# Altium schematic sheet Sheet.SchDoc
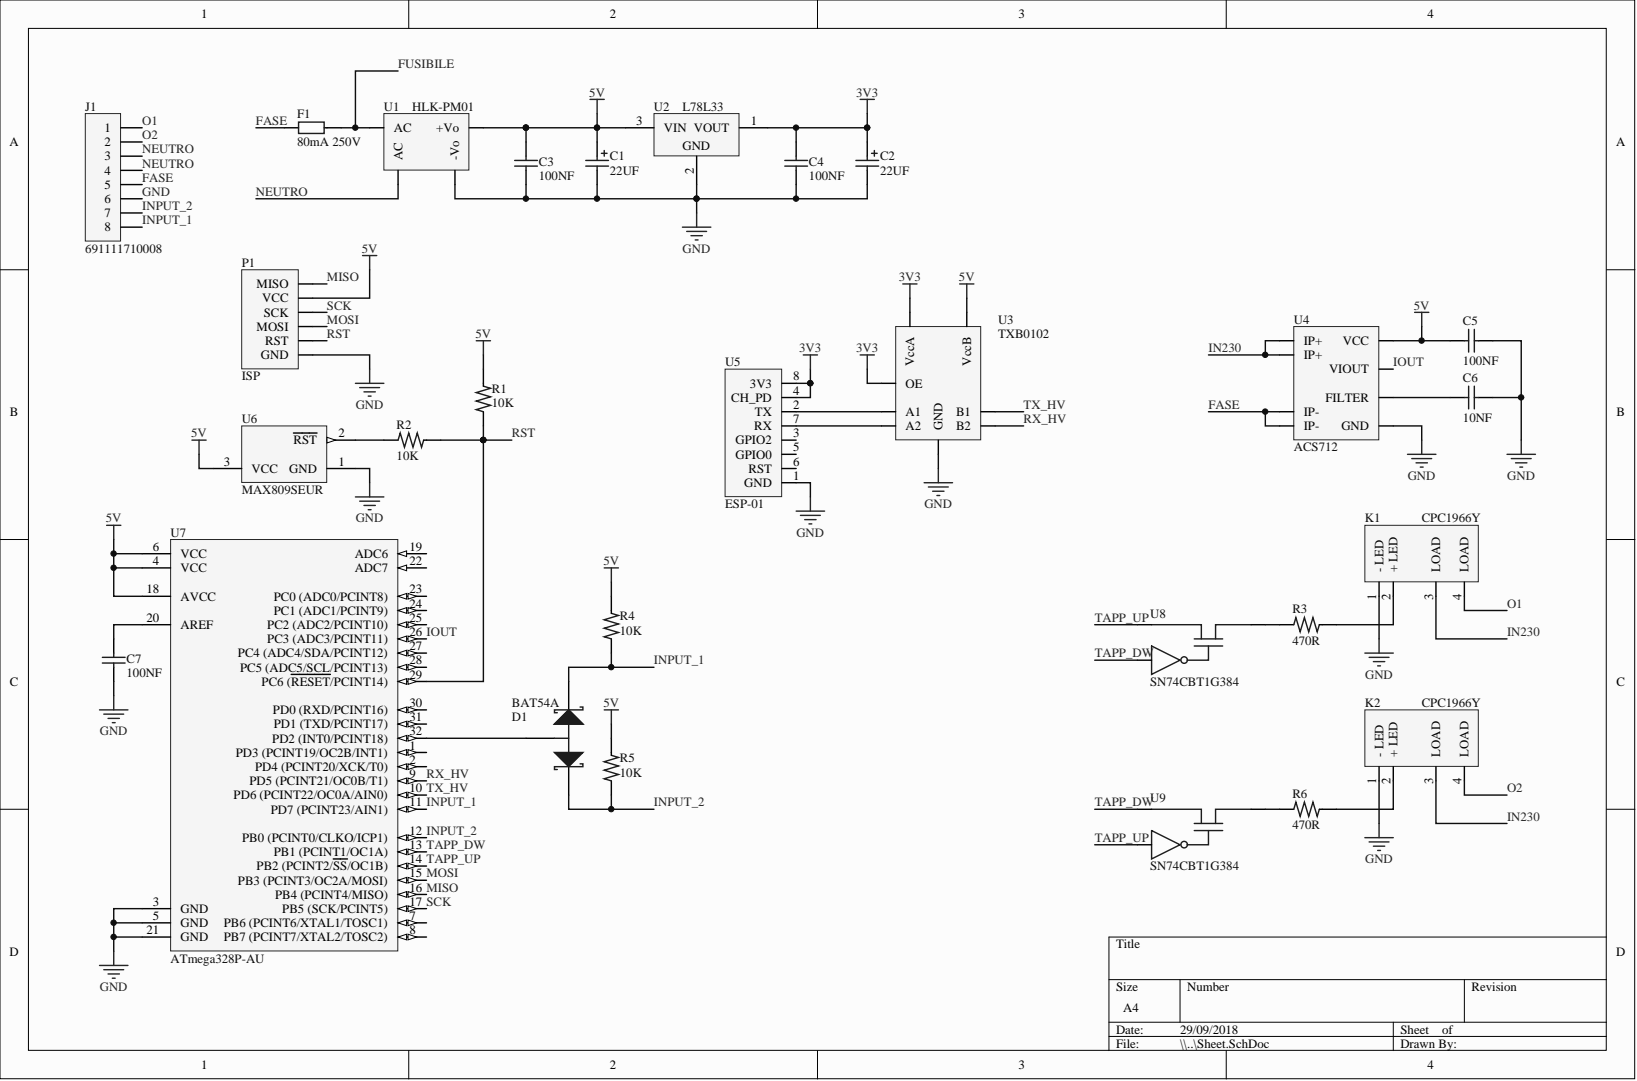
[diagram p0: Sheet.SchDoc]

## Parts
C1  value 22UF  [CASEB]  (hidden comment: SMD Tantalum Cap)
C2  value 22UF  [CASEB]  (hidden comment: SMD Tantalum Cap)
C3  value 100NF  [C0603]  (hidden comment: SMD Ceramic Cap)
C4  value 100NF  [C0603]  (hidden comment: SMD Ceramic Cap)
C5  value 100NF  [C0603]  (hidden comment: SMD Ceramic Cap)
C6  value 10NF  [C0603]  (hidden comment: SMD Ceramic Cap)
C7  value 100NF  [C0603]  (hidden comment: SMD Ceramic Cap)
D1  BAT54A  [SOT23]
F1  value 80mA 250V  [Fuse]  (hidden comment: Fuse)
J1  691111710008  [691111710008]
K1  CPC1966Y  [CPC1966Y]
K2  CPC1966Y  [CPC1966Y]
P1  ISP  [HDR2X3]
R1  value 10K  [R0603]  (hidden comment: SMD Resistor)
R2  value 10K  [R0603]  (hidden comment: SMD Resistor)
R3  value 470R  [R0603]  (hidden comment: SMD Resistor)
R4  value 10K  [R0603]  (hidden comment: SMD Resistor)
R5  value 10K  [R0603]  (hidden comment: SMD Resistor)
R6  value 470R  [R0603]  (hidden comment: SMD Resistor)
U1  HLK-PM01  [HLK-PM01]
U2  value L78L33  [SOT89]  (hidden comment: L78LXX)
U3  TXB0102  [SSOP8]
U4  ACS712  [SO8]
U5  ESP-01  [ESP-01]
U6  MAX809SEUR  [SOT23]
U7  ATmega328P-AU  [32A]
U8  SN74CBT1G384  [SOT23-5]
U9  SN74CBT1G384  [SOT23-5]

## Nets
3V3: C2.1 C4.1 U2.1 U3.3(VccA) U3.6(OE) U5.4(CH_PD) U5.8(3V3)
5V: C1.1 C3.2 C5.1 P1.2(VCC) R1.2 R4.2 R5.2 U1.4(+Vo) U2.3 U3.7(VccB) U4.8(VCC) U6.3 U7.4(VCC) U7.6(VCC) U7.18(AVCC) U8.5 U9.5
FASE: F1.1 J1.5 U4.3(IP-) U4.4(IP-)
FUSIBILE: F1.2 U1.1(AC)
GND: C1.2 C2.2 C3.1 C4.2 C5.2 C6.2 C7.2 J1.6 K1.1(-_LED) K2.1(-_LED) P1.6(GND) U1.3(-Vo) U2.2 U3.2(GND) U4.5(GND) U5.1(GND) U6.1 U7.3(GND) U7.5(GND) U7.21(GND) U8.3 U9.3
IN230: K1.3(LOAD) K2.3(LOAD) U4.1(IP+) U4.2(IP+)
INPUT_1: D1.2 J1.8 R4.1 U7.11(PD7_(PCINT23/AIN1))
INPUT_2: D1.1 J1.7 R5.1 U7.12(PB0_(PCINT0/CLKO/ICP1))
IOUT: U4.7(VIOUT) U7.26(PC3_(ADC3/PCINT11))
MISO: P1.1(MISO) U7.16(PB4_(PCINT4/MISO))
MOSI: P1.4(MOSI) U7.15(PB3_(PCINT3/OC2A/MOSI))
NEUTRO: J1.3 J1.4 U1.2(AC)
O1: J1.1 K1.4(LOAD)
O2: J1.2 K2.4(LOAD)
RST: P1.5(RST) R1.1 R2.2 U7.29(PC6_(RESET/PCINT14))
RX_HV: U3.1(B2) U7.9(PD5_(PCINT21/OC0B/T1))
SCK: P1.3(SCK) U7.17(PB5_(SCK/PCINT5))
TAPP_DW: U7.13(PB1_(PCINT1/OC1A)) U8.4 U9.1
TAPP_UP: U7.14(PB2_(PCINT2/SS/OC1B)) U8.1 U9.4
TX_HV: U3.8(B1) U7.10(PD6_(PCINT22/OC0A/AIN0))
NetC6_1: C6.1 U4.6(FILTER)
NetC7_1: C7.1 U7.20(AREF)
NetD1_3: D1.3 U7.32(PD2_(INT0/PCINT18))
NetK1_2: K1.2(+_LED) R3.2
NetK2_2: K2.2(+_LED) R6.2
NetR2_1: R2.1 U6.2
NetR3_1: R3.1 U8.2
NetR6_1: R6.1 U9.2
NetU3_4: U3.4(A2) U5.7(RX)
NetU3_5: U3.5(A1) U5.2(TX)

Not connected: U5 3(GPIO2) 5(GPIO0) 6(RST); U7 1(PD3_(PCINT19/OC2B/INT1)) 2(PD4_(PCINT20/XCK/T0)) 7 8 19(ADC6) 22(ADC7) 23(PC0_(ADC0/PCINT8)) 24(PC1_(ADC1/PCINT9)) 25 27(PC4_(ADC4/SDA/PCINT12)) 28(PC5_(ADC5/SCL/PCINT13)) 30(PD0_(RXD/PCINT16)) 31(PD1_(TXD/PCINT17))

## Library data of the parts
C1 C2 = A0016: CAP TAN 22UF CASE B SMD; Manufacturer: GENERIC; Database Table Name: Anagrafica; Code: A0016; Component Kind: Standard; LatestRevisionDate: 17-Jul-2002; LatestRevisionNote: Re-released for DXP Platform.; Library Name: DB.DbLib; PackageDescription: Polarized Capacitor (Radial); 2 Leads; PackageReference: RB7.6-15; Published: 8-Jun-2000; Publisher: Altium Limited
C3-C5 C7 = A0013: CAP 100NF 25V 0603 SMD; Manufacturer: GENERIC; Database Table Name: Anagrafica; Code: A0013; Component Kind: Standard; LatestRevisionDate: 29-May-2009; LatestRevisionNote: IPC-7351 Footprint Added.; Library Name: DB.DbLib; PackageDescription: Chip Capacitor; PackageReference: C1206; Published: 8-Jun-2000; Publisher: Altium Limited
C6 = A0052: CAP 10NF 50V 0603 SMD; Manufacturer: GENERIC; Database Table Name: Anagrafica; Code: A0052; Component Kind: Standard; LatestRevisionDate: 29-May-2009; LatestRevisionNote: IPC-7351 Footprint Added.; Library Name: DB.DbLib; PackageDescription: Chip Capacitor; PackageReference: C1206; Published: 8-Jun-2000; Publisher: Altium Limited
D1 = A0021: DIODE BAT54A SOT23 SMD; Manufacturer: GENERIC; Database Table Name: Anagrafica; Code: A0021; Component Kind: Standard; ComponentLink1Description: Manufacturer Link; ComponentLink1URL: http://www.semiconductors.philips.com/; ComponentLink2Description: Datasheet; ComponentLink2URL: http://www.semiconductors.philips.com/acrobat/datasheets/BAT54_SERIES_4.pdf; DatasheetVersion: 4-Mar-2002; LatestRevisionDate: 12-Dec-2006; LatestRevisionNote: IPC-7351 Footprint Added.; Library Name: DB.DbLib; PackageDescription: 3-Pin SOT-23 Package 0.95 mm Pitch, 2.3 mm Lead Span; PackageReference: SOT23; PackageVersion: May-1999; Published: 17-Sep-2003; Value: BAT54A; Publisher: Altium Limited
F1 = A0053: FUSE 80mA 250V SMD; Manufactuer P/N: 3403.0155.24; Manufacturer: SCHURTER; Database Table Name: Anagrafica; Code: A0053; Component Kind: Standard; LatestRevisionDate: 29-May-2009; LatestRevisionNote: IPC-7351 Footprint Added.; Library Name: DB.DbLib; PackageDescription: Chip Resistor; PackageReference: J1-0603; Published: 8-Jun-2000; Publisher: Altium Limited
J1 = A0045: MORS 8 POLI P=5.08 PTH; Manufacturer: WURTH; partNo: 691111710003; ComponentLink1 Description: Manufacturer; ComponentLink2 Description: Datasheet; Database Table Name: Anagrafica; NoPins: 3; Code: A0045; Component Kind: Standard; ComponentLink1URL: http://www.we-online.com; ComponentLink2URL: http://katalog.we-online.de/em/datasheet/6911117100xx.pdf; Library Name: DB.DbLib; Manufactuer P/N: 691111710008; Created by: Karrer Zheng; Created Date: 6 Novenber 2013
K1 K2 = A0046: RELAY CPC1966Y PTH; Manufacturer: IXYS; Database Table Name: Anagrafica; Code: A0046; Component Kind: Standard; Library Name: DB.DbLib; Manufactuer P/N: CPC1966Y; Value: CPC1966Y
P1 = A0055: ISP 2X3 2.54mm PTH; Database Table Name: Anagrafica; Code: A0055; Component Kind: Standard; LatestRevisionDate: 17-Jul-2002; LatestRevisionNote: Re-released for DXP Platform.; Library Name: DB.DbLib; Publisher: Altium Limited
R1 R2 R4 R5 = A0003: RES 10K 1% 0603 SMD; Manufacturer: GENERIC; Database Table Name: Anagrafica; Code: A0003; Component Kind: Standard; LatestRevisionDate: 29-May-2009; LatestRevisionNote: IPC-7351 Footprint Added.; Library Name: DB.DbLib; PackageDescription: Chip Resistor; PackageReference: J1-0603; Published: 8-Jun-2000; Tolerance: 1%; Publisher: Altium Limited
R3 R6 = A0009: RES 470R 1% 0603 SMD; Manufacturer: GENERIC; Database Table Name: Anagrafica; Code: A0009; Component Kind: Standard; LatestRevisionDate: 29-May-2009; LatestRevisionNote: IPC-7351 Footprint Added.; Library Name: DB.DbLib; PackageDescription: Chip Resistor; PackageReference: J1-0603; Published: 8-Jun-2000; Tolerance: 1%; Publisher: Altium Limited
U1 = A0051: MODULO AC-DC 5V 3W PTH; Manufacturer: HI-LINK; Database Table Name: Anagrafica; Code: A0051; Component Kind: Standard; Library Name: DB.DbLib; Manufactuer P/N: HLK-PM01
U2 = A0030: IC REG LDO 3.3V 1A SOT89 SMD; Manufactuer P/N: L78L33ACUTR; Manufacturer: ST; Database Table Name: Anagrafica; Code: A0030; Component Kind: Standard; ComponentLink1Description: Manufacturer URL; ComponentLink1URL: http://www.st.com; ComponentLink2Description: Datasheet; ComponentLink2URL: http://www.st.com/internet/com/TECHNICAL_RESOURCES/TECHNICAL_LITERATURE/DATASHEET/CD00000446.pdf; DatasheetVersion: Feb-2011; Library Name: DB.DbLib; PackageDescription: 3-Pin SOT-89 Package 1.5 mm Pitch, 4.1 mm Lead Span; PackageReference: SOT-89; PackageVersion: 2011
U3 = A0034: IC TXB0102 SSOP8 SMD; Manufacturer: TI; Database Table Name: Anagrafica; Code: A0034; Component Kind: Standard; Library Name: DB.DbLib; Manufactuer P/N: TXB0102; Value: TXB0102
U4 = A0024: IC ACS712 30A SO8 SMD; Manufacturer: ALLEGRO; Database Table Name: Anagrafica; Code: A0024; Component Kind: Standard; Library Name: DB.DbLib; Manufactuer P/N: ACS712; Value: ACS712
U5 = A0054: MODULO WIFI ESP-01 PTH; Database Table Name: Anagrafica; Code: A0054; Component Kind: Standard; LatestRevisionDate: 17-Jul-2002; LatestRevisionNote: Re-released for DXP Platform.; Library Name: DB.DbLib; Manufactuer P/N: ESP-01; Publisher: Altium Limited
U6 = A0029: IC MAX809SEUR SOT23 SMD; Manufacturer: MAXIM; PartNumber: MCP809M3-2.93; CompType: MiscPower; Database Table Name: Anagrafica; High-Reliability: Y; PackageTopMarking: SRB*XTT; PowerWise: N; Code: A0029; Component Kind: Standard; ComponentLink1Description: Manufacturer URL; ComponentLink1URL: http://www.national.com/; ComponentLink2Description: MCP809 Datasheet; ComponentLink2URL: http://www.national.com/ds/MC/MCP809.pdf; ComponentLink3Description: MCP809 Web Page; ComponentLink3URL: http://www.national.com/pf/MC/MCP809.html; ComponentLink4Description: MF03A Package Page; ComponentLink4URL: http://www.national.com/packaging/folders/mf03a.html; DatasheetVersion: Jun-2001; Library Name: DB.DbLib; Manufactuer P/N: MAX809SEUR; PackageDescription: Molded SOT-23, .115 x .051 x .037in Body, 3 Lead, .075 in Pitch; PackageReference: MF03A; PackageVersion: revB, Mar-2003; PinCount: 3; RoHS: N; Value: MAX809SEUR
U7 = A0026: IC ATMEGA328P QFP32 SMD; Manufacturer: ATMEL; Database Table Name: Anagrafica; Code: A0026; Code_JEDEC: MS-026-ABA; Component Kind: Standard; ComponentLink1Description: Manufacturer URL; ComponentLink1URL: http://www.atmel.com/; ComponentLink2Description: ATmega48A/48PA/88A/88PA/168A/168PA/328/328P Datasheet; ComponentLink2URL: http://www.atmel.com/dyn/resources/prod_documents/doc8271.pdf; ComponentLink3Description: ATmega48A/48PA/88A/88PA/168A/168PA/328/328P Summary; ComponentLink3URL: http://www.atmel.com/dyn/resources/prod_documents/8271S.pdf; DatasheetVersion: revD, May-2011; Library Name: DB.DbLib; Manufactuer P/N: ATMEGA328P-AU; PackageDescription: 32-Lead, 7x7x1.0 mm Body, 0.8mm Lead Pitch, Thin Profile Plastic Quad Flat Package (TQFP); PackageReference: 32A; PackageVersion: revC, Oct-2010; Value: ATMEGA328P
U8 U9 = A0033: IC SN74CBT1G384DBV SOT23-5 SMD; Manufacturer: TI; Database Table Name: Anagrafica; Code: A0033; Component Kind: Standard; Library Name: DB.DbLib; Manufactuer P/N: SN74CBT1G384DBV; Value: SN74CBT1G384
